# Altium schematic sheet Power Monitor.SchDoc
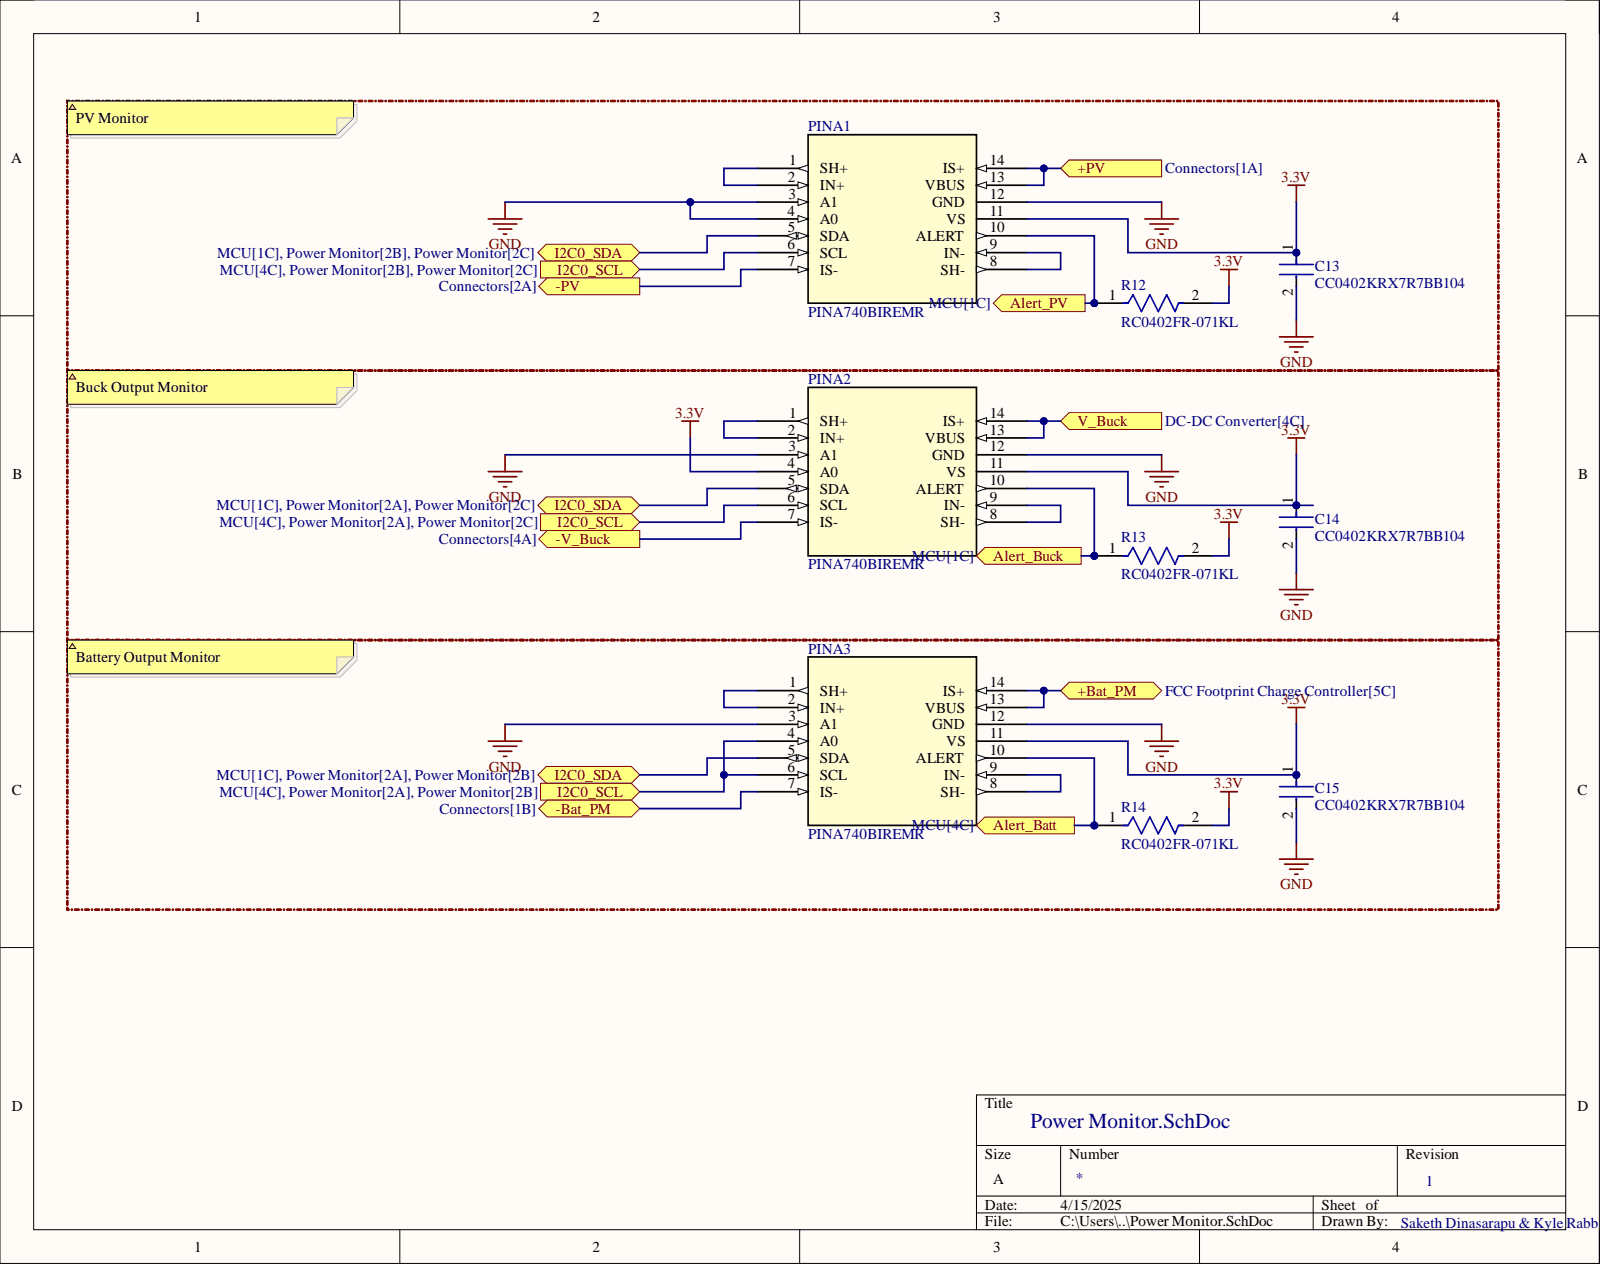
[diagram p0: Power Monitor.SchDoc]

## Parts
C13  CC0402KRX7R7BB104  [FP-CC0402-MFG]
C14  CC0402KRX7R7BB104  [FP-CC0402-MFG]
C15  CC0402KRX7R7BB104  [FP-CC0402-MFG]
PINA1  PINA740BIREMR  [VQFN14_REM_TEX]  (value not drawn)
PINA2  PINA740BIREMR  [VQFN14_REM_TEX]  (value not drawn)
PINA3  PINA740BIREMR  [VQFN14_REM_TEX]  (value not drawn)
R12  RC0402FR-071KL  [FP-RC0402-0_4-IPC_A]
R13  RC0402FR-071KL  [FP-RC0402-0_4-IPC_A]
R14  RC0402FR-071KL  [FP-RC0402-0_4-IPC_A]

## Ports
+Bat_PM, +PV, -Bat_PM, -PV, -V_Buck, Alert_Batt, Alert_Buck, Alert_PV, I2C0_SCL, I2C0_SDA, V_Buck

## Nets
3.3V: C13.1 C14.1 C15.1 PINA1.11(VS) PINA2.4(A0) PINA2.11(VS) PINA3.11(VS) R12.2 R13.2 R14.2
GND: C13.2 C14.2 C15.2 PINA1.3(A1) PINA1.4(A0) PINA1.12(GND) PINA2.3(A1) PINA2.12(GND) PINA3.3(A1) PINA3.12(GND)
-V_Buck: PINA2.7(IS-) ; port -V_Buck
Alert_Batt: PINA3.10(ALERT) R14.1 ; port Alert_Batt
Alert_Buck: PINA2.10(ALERT) R13.1 ; port Alert_Buck
Alert_PV: PINA1.10(ALERT) R12.1 ; port Alert_PV
I2C0_SCL: PINA1.6(SCL) PINA2.6(SCL) PINA3.4(A0) PINA3.6(SCL) ; port I2C0_SCL
I2C0_SDA: PINA1.5(SDA) PINA2.5(SDA) PINA3.5(SDA) ; port I2C0_SDA
V_Buck: PINA2.13(VBUS) PINA2.14(IS+) ; port V_Buck
NetPINA1_1: PINA1.1(SH+) PINA1.2(IN+)
NetPINA1_7: PINA1.7(IS-)
NetPINA1_8: PINA1.8(SH-) PINA1.9(IN-)
NetPINA1_13: PINA1.13(VBUS) PINA1.14(IS+)
NetPINA2_1: PINA2.1(SH+) PINA2.2(IN+)
NetPINA2_8: PINA2.8(SH-) PINA2.9(IN-)
NetPINA3_1: PINA3.1(SH+) PINA3.2(IN+)
NetPINA3_7: PINA3.7(IS-)
NetPINA3_8: PINA3.8(SH-) PINA3.9(IN-)
NetPINA3_13: PINA3.13(VBUS) PINA3.14(IS+)

## Library data of the parts
C13-C15 = CMP-03422-000048-1: CAP CER 0.1UF 16V X7R 0402; Manufacturer: YAGEO; Automotive Grade: NA; Capacitance: 100 nF; Material: Ceramic; Temperature Coefficient: 30ppm/°C; Tolerance: 0.1; Value: 100 nF; Automotive: No; Category: Cap; Component Kind: Standard; ComponentLink2Description: Datasheet; ComponentLink2URL: http://www.yageo.com/documents/recent/UPY-GP_NP0_16V-to-50V_16.pdf; Device Class L1: Passive Components; Device Class L2: Capacitors; Device Class L3: Ceramic Capacitors; Digikey Description: CAP CER 0.1UF 16V X7R 0402; Footprint Url: http://www.yageo.com/exep/pages/download/literatures/UPY-C_GEN_24.pdf; Height: 0.55mm; Ipc Land Pattern Name: CAPC10050X50; Lead Free: Yes; Library Name: Altium Content Vault; Manufacturer Part Number: CC0402KRX7R7BB104; Mouser Description: 0402 0.1 uF 16 V ±10 % Tolerance X7R SMT Multilayer Ceramic Capacitor; Octopart: https://octopart.com/cc0402krx7r7bb104-yageo-42244624; Package: CC0402; RoHS: TRUE; Temperature: -55°C to +125°C; Temperature Characteristic: X7R; Temperature Range High: +125°C; Temperature Range Low: -55°C; Voltage Rating: 16 V
PINA1-PINA3 = PINA740BIREMR: No Description Available; Mfr_Name: Texas Instruments; Component Kind: Standard; Library Name: PINA740BIREMR.IntLib; RefDes: RefDes; Type: Linear
R12-R14 = CMP-2002-07472-3: RES 1K OHM 1% 1/16W 0402; Max Power Dissipation: 63mW; Power Rating: 62.5mW; Resistance: 1kR; Temperature Coefficient: 100ppm/°C; Voltage Rating: 50V; Case Code (Imperial): 0402; Case Code (Metric): 1005; Case/Package: 0402; Component Kind: Standard; Construction: Rectangular; Depth: 0.5mm; Features: Moisture Resistant; Height: 0.32mm; Lead Free: Lead Free; Length: 1mm; Library Name: Altium Content Vault; Max Operating Temperature: 155°C; Military Standard: Not; Min Operating Temperature: -55°C; Number of Pins: 2; Number of Terminations: 2; Packaging: Tape and Reel; Reach SVHC Compliant: Yes; Resistance Tolerance: 1%; Resistor Type: Thick Film; RoHS Compliant: Yes; Series: RC; Size Code: 0402; Technology: THICK FILM; Termination: SMD/SMT; Tolerance: 1%; Voltage Rating (DC): 50V; Width: 0.5mm
